# Altium schematic sheet Third Band Filter.SchDoc
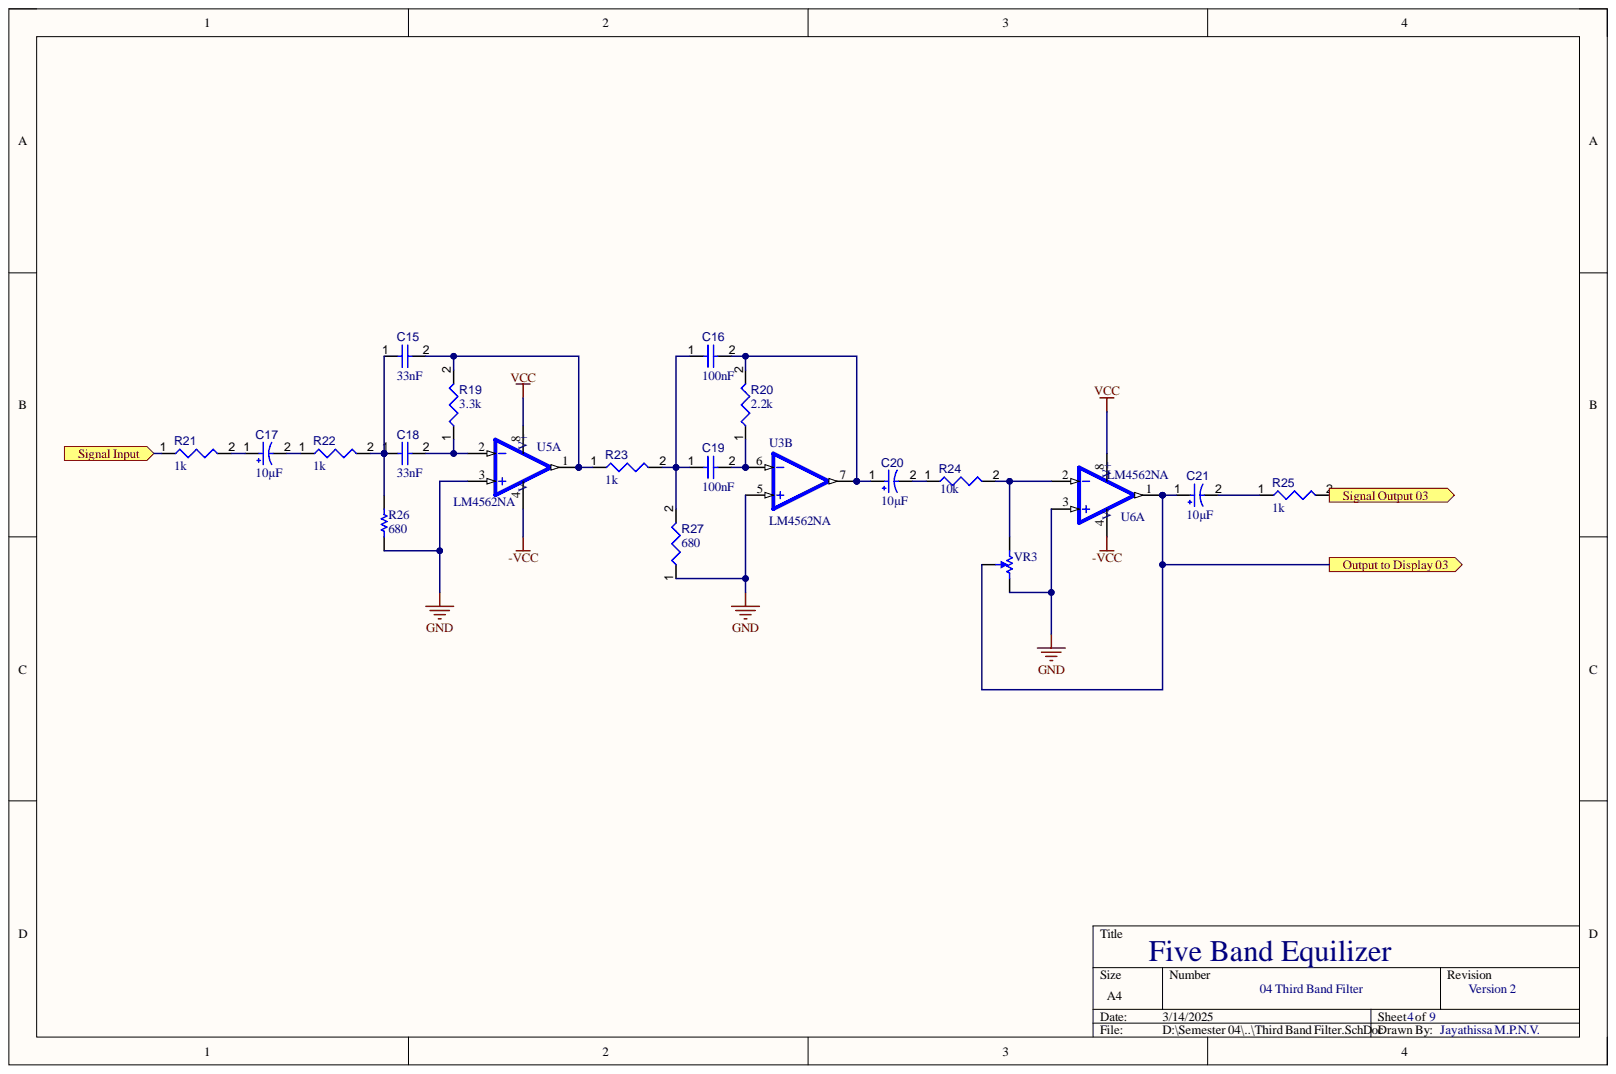
[diagram p0: Third Band Filter.SchDoc]

## Parts
C15  value 33nF  [CAPC1005X56X25NL10T15]  (hidden comment: Capacitor 6.8 nF +/- 10% 16 V 0402)
C16  value 100nF  [CAPC0402(1005)60_L]  (hidden comment: Capacitor 22nF +/-20% 10V 0402)
C17  value 10µF  [PNSC-6TPE150MAZB_L]  (hidden comment: Capacitor 6.8µF +/-20% 35V B2)
C18  value 33nF  [CAPC1005X56X25NL10T15]  (hidden comment: Capacitor 6.8 nF +/- 10% 16 V 0402)
C19  value 100nF  [CAPC0402(1005)60_L]  (hidden comment: Capacitor 22nF +/-20% 10V 0402)
C20  value 10µF  [PNSC-6TPE150MAZB_L]  (hidden comment: Capacitor 6.8µF +/-20% 35V B2)
C21  value 10µF  [PNSC-6TPE150MAZB_L]  (hidden comment: Capacitor 6.8µF +/-20% 35V B2)
R19  value 3.3k  [RESC0402(1005)_L]  (hidden comment: Resistor 232K +/-1 % 0402 63 mW)
R20  value 2.2k  [RESC0402(1005)_L]  (hidden comment: Resistor 47K5 +/-1 % 0402 63 mW)
R21  value 1k  [RESC0805(2012)_L]  (hidden comment: Resistor 1K +/-5% 0805 125 mW)
R22  value 1k  [RESC0402(1005)_L]  (hidden comment: Resistor 47K5 +/-1 % 0402 63 mW)
R23  value 1k  [RESC1005X40X25ML10T10]  (hidden comment: Resistor 15k +/-1% 0402 63 mW)
R24  value 10k  [RESC0402(1005)_L]  (hidden comment: Resistor 10K  +/-1 % 0402 63 mW)
R25  value 1k  [RESC0805(2012)_L]  (hidden comment: Resistor 1K +/-5% 0805 125 mW)
R26  value 680  [RESC1005X40X25ML05T10]  (hidden comment: Resistor 51k +/-5% 0402 63 mW)
R27  value 680  [RESC1005X40X25ML10T10]  (hidden comment: Resistor 15k +/-1% 0402 63 mW)
U3  LM4562NA  [DIP794W45P254L959H508Q8]  (part B)
U5  LM4562NA  [DIP794W45P254L959H508Q8]  (part A)
U6  LM4562NA  [DIP794W45P254L959H508Q8]  (part A)
VR3  3296W-1-102LF  [BOUR-3296W-1-3]  (value not drawn)

## Ports
Output to Display 03, Signal Input, Signal Output 03

## Nets
-VCC: U5.4 U6.4
GND: R26.1 R27.1 U3.5 U5.3(V-) U6.3(V-) VR3.2
VCC: U5.8 U6.8
Output to Display 03: C21.1 U6.1 VR3.3 ; port Output to Display 03
Signal Input: R21.1 ; port Signal Input
Signal Output 03: R25.2 ; port Signal Output 03
NetC15_1: C15.1 C18.1 R22.2 R26.2
NetC15_2: C15.2 R19.2 R23.1 U5.1
NetC16_1: C16.1 C19.1 R23.2 R27.2
NetC16_2: C16.2 C20.1 R20.2 U3.7
NetC17_1: C17.1 R21.2
NetC17_2: C17.2 R22.1
NetC18_2: C18.2 R19.1 U5.2
NetC19_2: C19.2 R20.1 U3.6
NetC20_2: C20.2 R24.1
NetC21_2: C21.2 R25.1
NetR24_2: R24.2 U6.2 VR3.1

## Library data of the parts
C15 C18 = CMP-001-00000-6: Chip Capacitor, 6.8 nF, +/- 10%, 16 V, 0402 (1005 Metric); Capacitor Type: Ceramic; Voltage Rating: 16V; Case/Package: 0402; Component Kind: Standard; Max Operating Temperature: 125°C; Min Operating Temperature: -55°C; Mounting Technology: SM; Pins: 2; RoHS Compliant: True; Tolerance: 10%; Library Name: Nirwan Jayathissa
C16 C19 = CMP-001-00062-3: Chip Capacitor, 22nF +/-20%, 10V, 0402, Thickness 0.6 mm; Tolerance: 10%; Case/Package: 0402; Component Kind: Standard; Max Operating Temperature: 85°C; Min Operating Temperature: -55°C; Mounting Technology: SMT; Pins: 2; Voltage Rating: 10V; Library Name: Nirwan Jayathissa
C17 C20 C21 = CMP-001-00059-3: Molded Tantalum Polymer Capacitor, 6.8 uF, +/- 20%, 35 V, -55 to 105 degC, 2-Pin SMD, RoHS, Tape and Reel; Case/Package: 1210; Component Kind: Standard; Max Operating Temperature: 105°C; Min Operating Temperature: -55°C; Mounting Technology: SMT; Pins: 2; Tolerance: 20%; Voltage Rating: 35V; Library Name: Nirwan Jayathissa
R19 = CMP-009-00127-3: Chip Resistor, 232 kOhms, +/-1 %, 63 mW, -55 to 155 degC, 0402; Power: 63mW; Tolerance: 1%; Case/Package: 0402; Component Kind: Standard; Pins: 2; Library Name: Nirwan Jayathissa
R20 R22 = CMP-009-00137-3: Chip Resistor, 47,5 kOhms, +/-1 %, 63 mW, -55 to 155 degC, 0402; Power: 63mW; Tolerance: 1%; Case/Package: 0402; Component Kind: Standard; Max Operating Temperature: 155°C; Min Operating Temperature: -55°C; Pins: 2; Library Name: Nirwan Jayathissa
R21 R25 = CMP-009-00118-3: Chip Resistor, 1 kOhms, +/-5%, 125 mW, -55 to 155 degC, 0805; Power: 125mW; Tolerance: 5%; Case/Package: 0805; Component Kind: Standard; Max Operating Temperature: 155°C; Min Operating Temperature: -55°C; Pins: 2; Library Name: Nirwan Jayathissa
R23 R27 = CMP-009-00026-5: Chip Resistor, 15 KOhm, +/- 1%, 0.063 W, -55 to 155 degC, 0402 (1005 Metric); Power: 63mW; Case/Package: 0402; Component Kind: Standard; Max Operating Temperature: 155°C; Min Operating Temperature: -55°C; Mounting Technology: SM; Pins: 2; RoHS Compliant: True; Tolerance: 1%; Library Name: Nirwan Jayathissa
R24 = CMP-009-00149-3: Chip Resistor, 10 kOhms, +/-1 %, 63 mW, -55 to 125 degC, 0402; Power: 63mW; Tolerance: 1%; Case/Package: 0402; Component Kind: Standard; Max Operating Temperature: 155°C; Min Operating Temperature: -55°C; Pins: 2; Library Name: Nirwan Jayathissa
R26 = CMP-009-00000-5: Chip Resistor, 51 KOhm, +/- 5%, 0.063 W, -55 to 155 degC, 0402 (1005 Metric); Power: 63mW; Case/Package: 0402; Component Kind: Standard; Max Operating Temperature: 155°C; Min Operating Temperature: -55°C; Mounting Technology: SM; Pins: 2; RoHS Compliant: True; Tolerance: 5%; Library Name: Nirwan Jayathissa
U3 U5 U6 = CMP-014-00002-1: LM4562 2 - Dual Channels per IC Audio Amplifier Evaluation Board; MF: Texas Instruments; Availability: In Stock; Case/Package: DIP-8 Texas Instruments; Check_prices: https://www.snapeda.com/parts/LM4562NA/Texas+Instruments/view-part/?ref=eda; Component Kind: Standard; Component Type: Standard; Design Item ID: LM4562NA; MP: LM4562NA; Pins: 8; Price: None; Purchase-URL: https://pricing.snapeda.com/search/part/LM4562NA/?ref=eda; SnapEDA_Link: https://www.snapeda.com/parts/LM4562NA/Texas+Instruments/view-part/?ref=snap; Source: LM4562NA.SchLib; Library Name: Nirwan Jayathissa
VR3 = CMP-009-00037-2: Square Trimpot(R) Trimming Potentiometer, 1000 Ohm, -55 to 125 degC, 3-Pin THD, RoHS, Tube; Manufacturer: Bourns; Power: 500mW; Resistance: 1k; Tolerance: 10%; Voltage Rating: 300V; Case/Package: 3296W-1-3; Component Kind: Standard; Composition: Potentiometer; Datasheet URL: http://www.bourns.com/docs/Product-Datasheets/3296.pdf; Datasheet Version: May-16; Manufacturer URL: http://www.bourns.com/; Max Operating Temperature: 150°C; Min Operating Temperature: -55°C; Mounting Technology: TH; Package Description: 3-Pin Through Hole Device, Body 9.53 x 4.83 mm, Pitch 2.54 mm; Package Quantity: 50; Package Reference: 3296W-1-3; Packaging: Rail/Tube; RoHS Compliant: True; Library Name: Nirwan Jayathissa
